# Altium schematic sheet Main Schematics.SchDoc("Main Schematics")
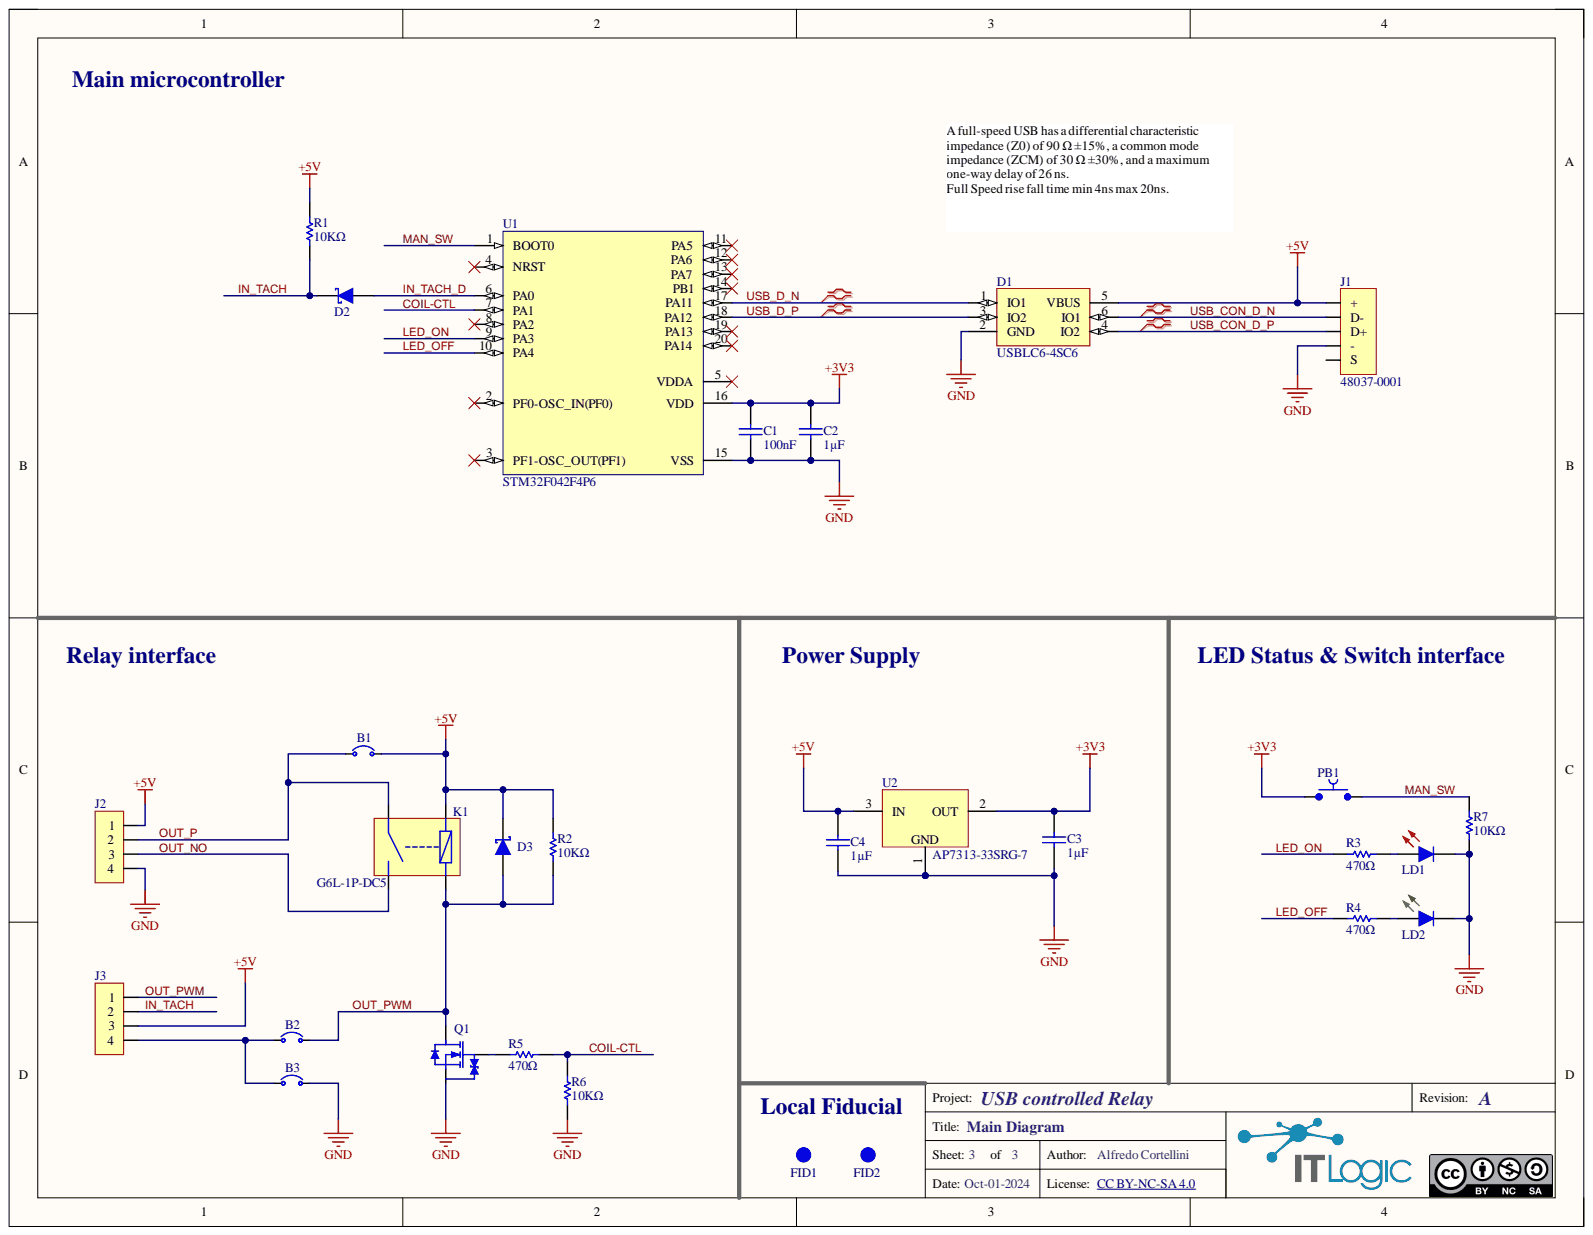
[diagram p0: Main Schematics.SchDoc]

## Parts
B1  _SMD-JUMP-0603  [_SMD-JUMP-0603]  (value not drawn)  (no BOM)
B2  _SMD-JUMP-0603  [_SMD-JUMP-0603]  (value not drawn)  (no BOM)
B3  _SMD-JUMP-0603  [_SMD-JUMP-0603]  (value not drawn)  (no BOM)
C1  100nF  [C0603-H90]
C2  1µF  [C0603-H90]
C3  1µF  [C0603-H90]
C4  1µF  [C0603-H90]
D1  USBLC6-4SC6  [SOT-236]
D2  MBR0530T1G  [SOD-323]  (value not drawn)
D3  MBR0530T1G  [SOD-323]  (value not drawn)
FID1  Local Fiducial  [FID-1-2MM]  (no BOM)
FID2  Local Fiducial  [FID-1-2MM]  (no BOM)
J1  48037-0001  [48037-0001]
J2  S4B-XH-A(LF)(SN)  [JST S4B-XH-A]  (value not drawn)
J3  70553-0038  [70553-0038]  (value not drawn)
K1  G6L-1P-DC5  [G6L-1P]
LD1  LTST-C191KRKT  [LED-0603-R]  (value not drawn)
LD2  LTST-C191KGKT  [LED-0603-G]  (value not drawn)
PB1  TL3302AF180QJ  [TL3302AFXXXQJ]  (value not drawn)
Q1  BSS138K-13  [SOT-23]  (value not drawn)
R1  10KΩ  [R0603-H55]
R2  10KΩ  [R0603-H55]
R3  470Ω  [R0603-H55]
R4  470Ω  [R0603-H55]
R5  470Ω  [R0603-H55]
R6  10KΩ  [R0603-H55]
R7  10KΩ  [R0603-H55]
U1  STM32F042F4P6  [TSSOP-20]
U2  AP7313-33SRG-7  [SOT-23]

## Nets
+3V3: C1.2 C2.2 C3.2 PB1.1 U1.16(VDD) U2.2
+5V: B1.1 C4.2 D1.5(VBUS) D3.1 J1.1(+) J2.1 J3.3 K1.8 R1.2 R2.2 U2.3
GND: B3.1 C1.1 C2.1 C3.1 C4.1 D1.2(GND) J1.4(-) J2.4 LD1.1 LD2.1 Q1.2 R6.1 R7.1 U1.15(VSS) U2.1
COIL-CTL: R5.2 R6.2 U1.7(PA1)
IN_TACH: D2.1 J3.2 R1.1
IN_TACH_D: D2.2 U1.6(PA0)
LED_OFF: R4.1 U1.10(PA4)
LED_ON: R3.1 U1.9(PA3)
MAN_SW: PB1.2 R7.2 U1.1(BOOT0)
OUT_NO: J2.3 K1.4
OUT_P: B1.2 J2.2 K1.2
OUT_PWM: B2.1 D3.2 J3.1 K1.5 Q1.3 R2.1
USB_CON_D_N: D1.6(IO1) J1.2(D-)
USB_CON_D_P: D1.4(IO2) J1.3(D+)
USB_D_N: D1.1(IO1) U1.17(PA11)
USB_D_P: D1.3(IO2) U1.18(PA12)
NetB2_2: B2.2 B3.2 J3.4
NetLD1_2: LD1.2 R3.2
NetLD2_2: LD2.2 R4.2
NetQ1_1: Q1.1 R5.1

Not connected: J1 S; U1 2(PF0-OSC_IN(PF0)) 3(PF1-OSC_OUT(PF1)) 4(NRST) 5(VDDA) 8(PA2) 11(PA5) 12(PA6) 13(PA7) 14(PB1) 19(PA13) 20(PA14)

## Library data of the parts
B1-B3 = _SMD-JUMP-0603: Option Jumper smd 0603; Case: 0603; Mount: Surface Mount; Library Name: IT Logic Library.IntLib
C1 = CGA3E2X7R1H104K080AA: Cap 0603 Case Ceramic 100nF 50V X7R; Manufacturer: TDK; Value: 100n; Capacitance: 100nF; Case: 0603; Component Kind: Standard; ComponentLink1Description: Data Sheet; ComponentLink1URL: https://product.tdk.com/system/files/dam/doc/product/capacitor/ceramic/mlcc/catalog/mlcc_commercial_general_en.pdf; Dielectric: X7R; Manufacturer Part Number: CGA3E2X7R1H104K080AA; Mount: Surface Mount; Voltage Rating: 50V; Library Name: IT Logic Library.IntLib
C2-C4 = C1608X7R1C105K080AC: Cap 0603 Case Ceramic 1uF 16V X7R; Manufacturer: TDK; Value: 1u; Capacitance: 1uF; Case: 0603; Component Kind: Standard; ComponentLink1Description: Data Sheet; ComponentLink1URL: https://www.murata.com/en-eu/products/productdetail?partno=GRM188Z71C475KE21%23; Dielectric: X7R; Manufacturer Part Number: C1608X7R1C105K080AC; Mount: Surface Mount; Voltage Rating: 16V; Library Name: IT Logic Library.IntLib
D1 = USBLC6-4SC6: ESD Protection Diodes / TVS Diodes 2 Line 2.5pF USB 2.0 ESD Protect SOT23-6; Manufacturer: STMicroelectronics; Case: STO23-6; Component Kind: Standard; ComponentLink1Description: Data Sheet; ComponentLink1URL: https://www.mouser.it/datasheet/2/389/usblc6_2-1852789.pdf; Manufacturer Part Number: USBLC6-4SC6; Mount: Surface Mount; Library Name: IT Logic Library.IntLib
D2 D3 = MBR0530T1G: Diode Schottky 30V 0.5A 2-Pin SOD-123; Manufacturer: ON Semiconductor; Case: SOD-123; Component Kind: Standard; ComponentLink1Description: Data Sheet; ComponentLink1URL: https://www.onsemi.com/pdf/datasheet/mbr0530t1-d.pdf; Current Rating: 0.5A; Manufacturer Part Number: MBR0530T1G; Mount: Surface Mount; Library Name: IT Logic Library.IntLib
FID1 FID2 = FID-1-2MM: Fiducial 1.0mm Diameter, 2.0mmil Mask; Library Name: IT Logic Library.IntLib
J1 = 48037-0001: Conn USB type A plug 4 pin Horizontal TH; Manufacturer: Molex; Current Rating: 1.5A; Voltage Rating: 30V; Component Kind: Standard; ComponentLink1Description: Data Sheet; ComponentLink1URL: https://www.molex.com/en-us/products/part-detail/480370001; Manufacturer Part Number: 48037-0001; Mount: Through Hole; Library Name: IT Logic Library.IntLib
J2 = S4B-XH-A(LF)(SN): Conn Shrouded, Series JST XH, 4 Pos Header,(2.50mm) Through Hole, Right Angle Tin; Current Rating: 3A; Voltage Rating: 250V; Component Kind: Standard; ComponentLink1Description: Data Sheet; ComponentLink1URL: https://datasheet.ciiva.com/726/exh-726400.pdf; Manufacturer: JST; Manufacturer Part Number: S4B-XH-A(LF)(SN); Mount: Through Hole; Library Name: IT Logic Library.IntLib
J3 = 70553-0038: Conn Shrouded, Series Molex 70058, 4 Pos Header, (2.54mm) Through Hole, Right Angle Tin; Current Rating: 3A; Voltage Rating: 250V; Component Kind: Standard; ComponentLink1Description: Data Sheet; ComponentLink1URL: https://www.molex.com/webdocs/datasheets/pdf/en-us/0705530038_PCB_HEADERS.pdf; Manufacturer: Molex; Manufacturer Part Number: 70553-0038; Mount: Through Hole; Library Name: IT Logic Library.IntLib
K1 = G6L-1P-DC5: Relay Low Signal Sealed SPST-NO 1A 5VDC Through Hole Tube; Manufacturer: Omron; Component Kind: Standard; ComponentLink1Description: Data Sheet; ComponentLink1URL: http://www.farnell.com/datasheets/1846831.pdf; Manufacturer Part Number: G6L-1P-DC5; Mount: Through Hole; Library Name: IT Logic Library.IntLib
LD1 = LTST-C191KRKT: LED 0603 Top Red 631nm 2-Pin; Manufacturer: Vishay Lite-On; Case: 0603; Component Kind: Standard; ComponentLink1Description: Data Sheet; ComponentLink1URL: https://optoelectronics.liteon.com/upload/download/DS22-2000-223/LTST-C191KRKT.PDF; Library Name: IT Logic Library.IntLib; Manufacturer Part Number: LTST-C191KRKT; Mount: Surface Mount
LD2 = LTST-C191KGKT: LED 0603 Top Green 571nm 2-Pin; Manufacturer: Vishay Lite-On; Case: 0603; Component Kind: Standard; ComponentLink1Description: Data Sheet; ComponentLink1URL: https://optoelectronics.liteon.com/upload/download/DS22-2000-228/LTST-C191KGKT.PDF; Library Name: IT Logic Library.IntLib; Manufacturer Part Number: LTST-C191KGKT; Mount: Surface Mount
PB1 = TL3302AF180QJ: Tactile Switch Rectangular 6.00x3.55mm. Height 4.3mm. J-Lead 50mA 12VDC 180gf SMD; Manufacturer: E-Switch; Voltage Rating: 12V; Component Kind: Standard; ComponentLink1Description: Data Sheet; ComponentLink1URL: https://sten-eswitch-13110800-production.s3.amazonaws.com/system/asset/product_line/data_sheet/159/TL3302.pdf; Current Rating: 50 mA; Library Name: IT Logic Library.IntLib; Manufacturer Part Number: TL3302AF180QJ; Mount: Through Hole
Q1 = BSS138K-13: Mosfet N 50V Vds 20V Vgs ESD 1.4R SOT-23; Manufacturer: Diodes; Case: SOT-23; Component Kind: Standard; ComponentLink1Description: Data Sheet; ComponentLink1URL: https://www.diodes.com/assets/Datasheets/BSS138K.pdf; Manufacturer Part Number: BSS138K-13; Mount: Surface Mount; Library Name: IT Logic Library.IntLib
R1 R2 R6 R7 = RC0603FR-0710KL: Resistor 0603 Case 10KR 1% 1/10W; Manufacturer: Yageo; Value: 10K; Voltage Rating: 150V; Case: 0603; Component Kind: Standard; ComponentLink1Description: Data Sheet; ComponentLink1URL: https://datasheet.ciiva.com/2519/pyu-r-int-thick-7-2519712.pdf; Manufacturer Part Number: RC0603FR-0710KL; Mount: Surface Mount; Resistance: 10KR; Library Name: IT Logic Library.IntLib
R3-R5 = RC0603FR-07470RL: Resistor 0603 Case 470R 1% 1/10W; Manufacturer: Yageo; Value: 470; Voltage Rating: 150V; Case: 0603; Component Kind: Standard; ComponentLink1Description: Data Sheet; ComponentLink1URL: https://datasheet.ciiva.com/11808/0900766b8106beab-11808155.pdf; Manufacturer Part Number: RC0603FR-07470RL; Mount: Surface Mount; Resistance: 470R; Library Name: IT Logic Library.IntLib
U1 = STM32F042F4P6: STM32 Arm Cortex-M0 USB line MCU 16 Kbytes of Flash 48 MHz CPU USB CAN CEC; Manufacturer: STMicroelectronics; Frequency: 48 MHz; Case: TSSOP-20; Component Kind: Standard; ComponentLink2Description: DataSheet; ComponentLink2URL: https://www.st.com/resource/en/datasheet/stm32f042f4.pdf; Manufacturer Part Number: STM32F042F4P6; Mount: Surface Mount; Library Name: IT Logic Library.IntLib
U2 = AP7313-33SRG-7: LDO 3.3V 150mA Out  6Vin 130mV Dropout, Quiescent current 65uA Startup 80µs SOT-23; Manufacturer: Diodes; Case: SOT-223; Component Kind: Standard; ComponentLink1Description: Data Sheet; ComponentLink1URL: https://www.diodes.com/assets/Datasheets/AP7313.pdf; Manufacturer Part Number: AP7313-33SRG-7; Mount: Surface Mount; Library Name: IT Logic Library.IntLib
